# Altium schematic sheet SmartChessMultiplex_SCH.SchDoc(SmartChessMultiplex_SCH)
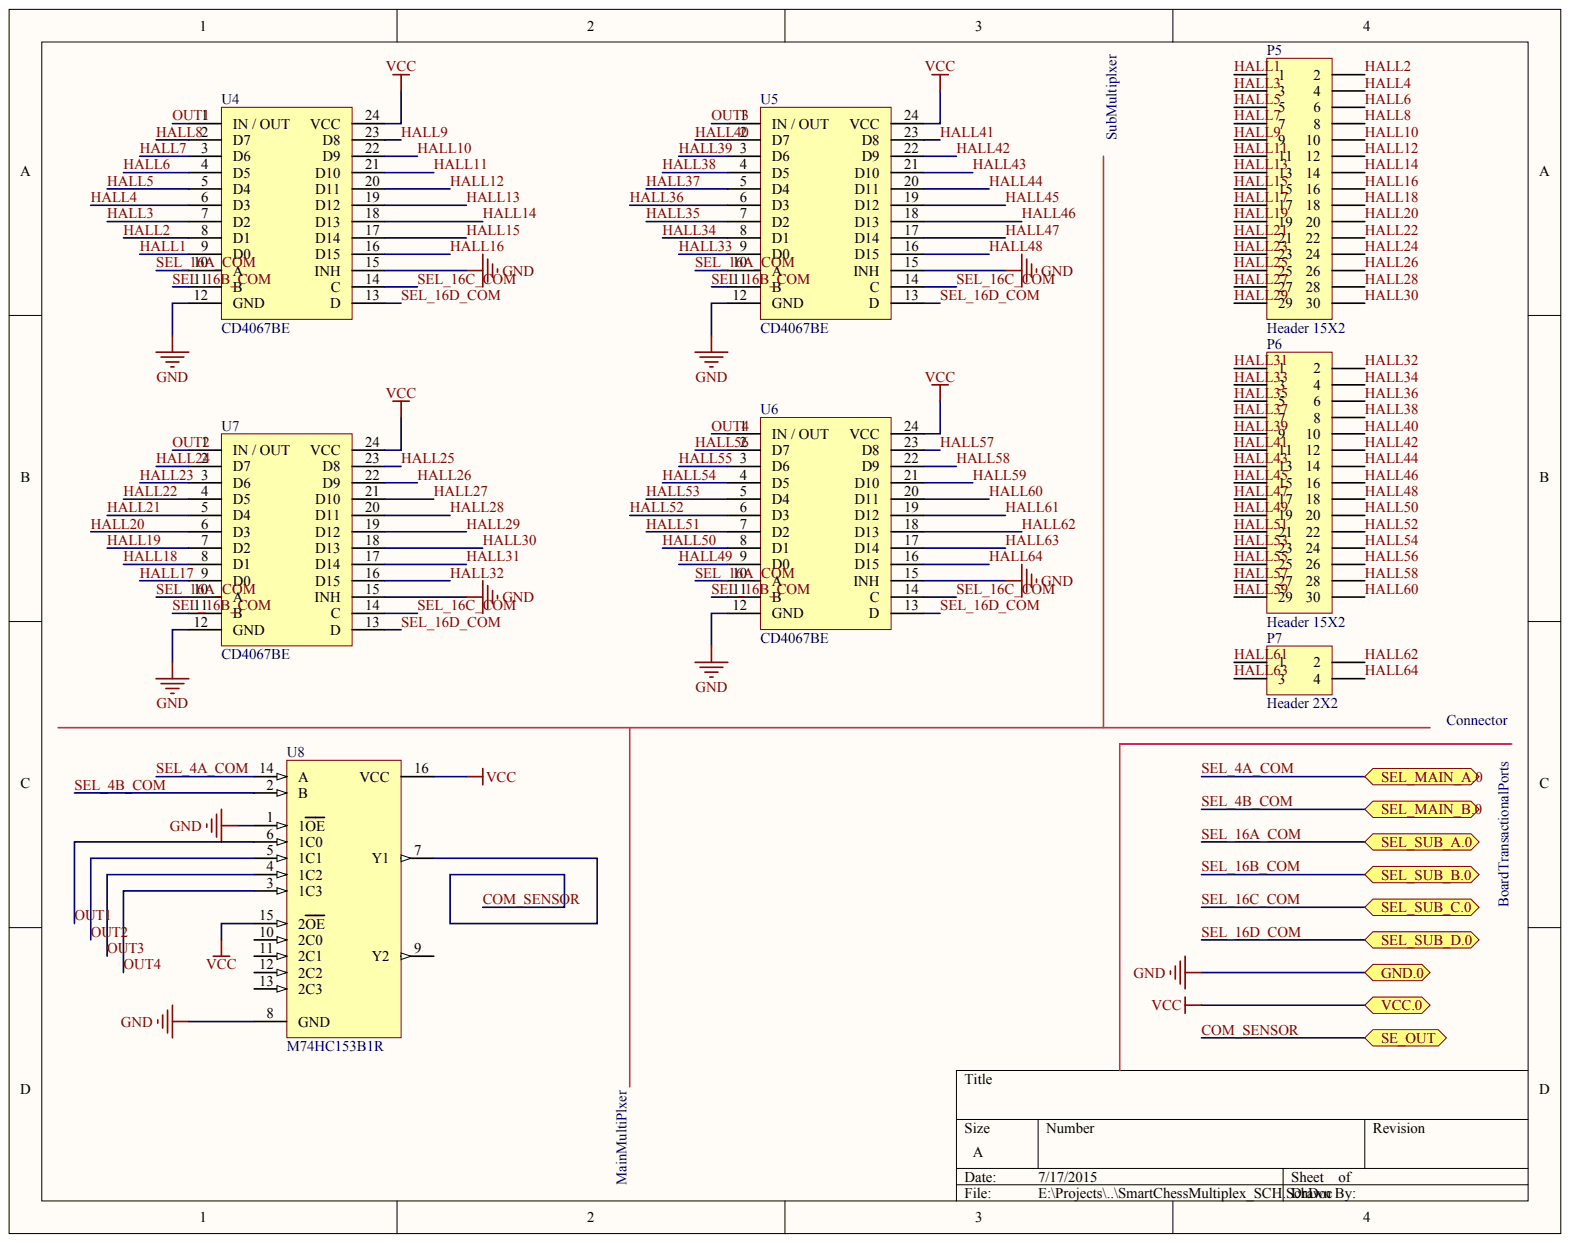
[diagram p0: SmartChessMultiplex_SCH.SchDoc]

## Parts
P5  Header 15X2
P6  Header 15X2
P7  Header 2X2
U4  CD4067BE
U5  CD4067BE
U6  CD4067BE
U7  CD4067BE
U8  M74HC153B1R

## Ports
GND.0, SE_OUT, SEL_MAIN_A.0, SEL_MAIN_B.0, SEL_SUB_A.0, SEL_SUB_B.0, SEL_SUB_C.0, SEL_SUB_D.0, VCC.0

## Nets
FINALOUT: U8.7(Y1) ; port SE_OUT
GND: U4.12(GND) U4.15(INH) U5.12(GND) U5.15(INH) U6.12(GND) U6.15(INH) U7.12(GND) U7.15(INH) U8.1(1OE) U8.8(GND) ; port GND.0
HALL1: P5.1 U4.9(D0)
HALL2: P5.2 U4.8(D1)
HALL3: P5.3 U4.7(D2)
HALL4: P5.4 U4.6(D3)
HALL5: P5.5 U4.5(D4)
HALL6: P5.6 U4.4(D5)
HALL7: P5.7 U4.3(D6)
HALL8: P5.8 U4.2(D7)
HALL9: P5.9 U4.23(D8)
HALL10: P5.10 U4.22(D9)
HALL11: P5.11 U4.21(D10)
HALL12: P5.12 U4.20(D11)
HALL13: P5.13 U4.19(D12)
HALL14: P5.14 U4.18(D13)
HALL15: P5.15 U4.17(D14)
HALL16: P5.16 U4.16(D15)
HALL17: P5.17 U7.9(D0)
HALL18: P5.18 U7.8(D1)
HALL19: P5.19 U7.7(D2)
HALL20: P5.20 U7.6(D3)
HALL21: P5.21 U7.5(D4)
HALL22: P5.22 U7.4(D5)
HALL23: P5.23 U7.3(D6)
HALL24: P5.24 U7.2(D7)
HALL25: P5.25 U7.23(D8)
HALL26: P5.26 U7.22(D9)
HALL27: P5.27 U7.21(D10)
HALL28: P5.28 U7.20(D11)
HALL29: P5.29 U7.19(D12)
HALL30: P5.30 U7.18(D13)
HALL31: P6.1 U7.17(D14)
HALL32: P6.2 U7.16(D15)
HALL33: P6.3 U5.9(D0)
HALL34: P6.4 U5.8(D1)
HALL35: P6.5 U5.7(D2)
HALL36: P6.6 U5.6(D3)
HALL37: P6.7 U5.5(D4)
HALL38: P6.8 U5.4(D5)
HALL39: P6.9 U5.3(D6)
HALL40: P6.10 U5.2(D7)
HALL41: P6.11 U5.23(D8)
HALL42: P6.12 U5.22(D9)
HALL43: P6.13 U5.21(D10)
HALL44: P6.14 U5.20(D11)
HALL45: P6.15 U5.19(D12)
HALL46: P6.16 U5.18(D13)
HALL47: P6.17 U5.17(D14)
HALL48: P6.18 U5.16(D15)
HALL49: P6.19 U6.9(D0)
HALL50: P6.20 U6.8(D1)
HALL51: P6.21 U6.7(D2)
HALL52: P6.22 U6.6(D3)
HALL53: P6.23 U6.5(D4)
HALL54: P6.24 U6.4(D5)
HALL55: P6.25 U6.3(D6)
HALL56: P6.26 U6.2(D7)
HALL57: P6.27 U6.23(D8)
HALL58: P6.28 U6.22(D9)
HALL59: P6.29 U6.21(D10)
HALL60: P6.30 U6.20(D11)
HALL61: P7.1 U6.19(D12)
HALL62: P7.2 U6.18(D13)
HALL63: P7.3 U6.17(D14)
HALL64: P7.4 U6.16(D15)
OUT1: U4.1(IN_/_OUT) U8.6(1C0)
OUT2: U7.1(IN_/_OUT) U8.5(1C1)
OUT3: U5.1(IN_/_OUT) U8.4(1C2)
OUT4: U6.1(IN_/_OUT) U8.3(1C3)
SEL_4A_COM: U8.14(A) ; port SEL_MAIN_A.0
SEL_4B_COM: U8.2(B) ; port SEL_MAIN_B.0
SEL_16A_COM: U4.10(A) U5.10(A) U6.10(A) U7.10(A) ; port SEL_SUB_A.0
SEL_16B_COM: U4.11(B) U5.11(B) U6.11(B) U7.11(B) ; port SEL_SUB_B.0
SEL_16C_COM: U4.14(C) U5.14(C) U6.14(C) U7.14(C) ; port SEL_SUB_C.0
SEL_16D_COM: U4.13(D) U5.13(D) U6.13(D) U7.13(D) ; port SEL_SUB_D.0
VCC: U4.24(VCC) U5.24(VCC) U6.24(VCC) U7.24(VCC) U8.15(2OE) U8.16(VCC) ; port VCC.0

Not connected: U8 9(Y2) 10(2C0) 11(2C1) 12(2C2) 13(2C3)

## Library data of the parts
P5-P7 U4-U8 = (no symbol name)
  P5-P7: LatestRevisionDate: 17-Jul-2002; LatestRevisionNote: Re-released for DXP Platform.
  U8: Published: 8-Jun-2000; LatestRevisionDate: 06-Jun-2005; LatestRevisionNote: Stylized 3D Model Added.; DatasheetVersion: Jan-1993; PackageVersion: Apr-1996; PackageReference: DIP16; PackageDescription: DIP; 16 Leads; Row Spacing 7.62 mm; Pitch 2.54 mm; ComponentLink1Description: Manufacturer Link; ComponentLink1URL: http://www.st.com/; ComponentLink2Description: Datasheet; ComponentLink2URL: http://www.st.com/stonline/products/literature/ds/1905/m74hc153.pdf
  P5-P7 U8: Publisher: Altium Limited
